# Altium schematic sheet CurrentSense.SchDoc
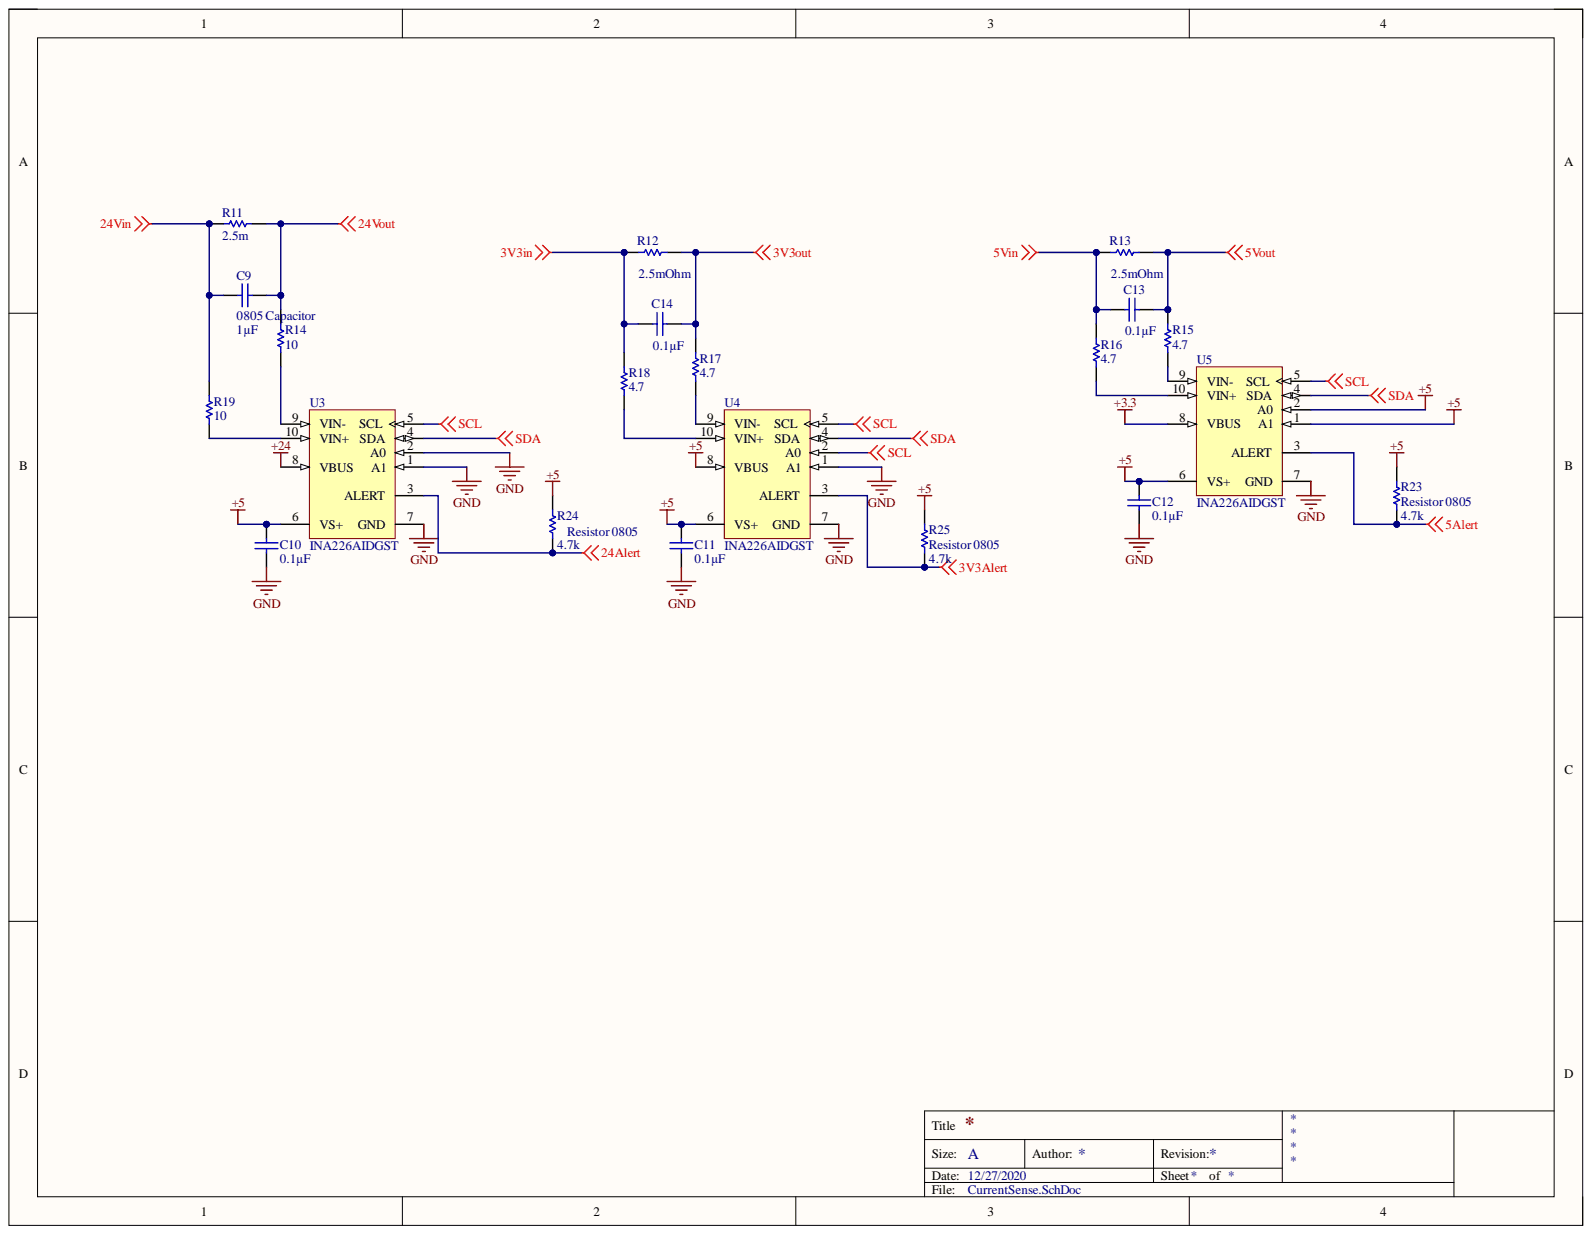
[diagram p0: CurrentSense.SchDoc]

## Parts
C9  0805 Capacitor  value 1µF  Case Size/Dimension: 0805  [Cap 0805]
C10  0805 Capacitor  value 0.1µF  [Cap 0805]
C11  0805 Capacitor  value 0.1µF  [Cap 0805]
C12  0805 Capacitor  value 0.1µF  [Cap 0805]
C13  0805 Capacitor  value 0.1µF  [Cap 0805]
C14  0805 Capacitor  value 0.1µF  [Cap 0805]
R11  2.5m  [Shunt Resistor]
R12  Resistor 0805  value 2.5mOhm  [RESC0805(2012)_N]
R13  value 2.5mOhm  [RESC0805(2012)_N]  (hidden comment: 2.5m)
R14  Resistor 0805  value 10  [RESC0805(2012)_N]
R15  Resistor 0805  value 4.7  [RESC0805(2012)_N]
R16  Resistor 0805  value 4.7  [RESC0805(2012)_N]
R17  Resistor 0805  value 4.7  [RESC0805(2012)_N]
R18  Resistor 0805  value 4.7  [RESC0805(2012)_N]
R19  Resistor 0805  value 10  [RESC0805(2012)_N]
R23  Resistor 0805  value 4.7k  [RESC0805(2012)_N]
R24  Resistor 0805  value 4.7k  [RESC0805(2012)_N]
R25  Resistor 0805  value 4.7k  [RESC0805(2012)_N]
U3  INA226AIDGST  [DGS0010A_N]
U4  INA226AIDGST  [DGS0010A_N]
U5  INA226AIDGST  [DGS0010A_N]

## Nets
3V3Alert: R25.1 U4.3(ALERT)
3V3in: C14.1 R12.1 R18.2
3V3out: C14.2 R12.2 R17.2
5Alert: C10.2 C11.2 C12.2 R23.1 R23.2 R24.2 R25.2 U3.6(VS+) U4.6(VS+) U4.8(VBUS) U5.1(A1) U5.2(A0) U5.3(ALERT) U5.6(VS+)
5Vin: C13.1 R13.1 R16.2
5Vout: C13.2 R13.2 R15.2
24Alert: R24.1 U3.3(ALERT) U3.8(VBUS)
24Vin: C9.1 R11.1 R19.2
24Vout: C9.2 R11.2 R14.2
+3.3: U5.8(VBUS)
GND: C10.1 C11.1 C12.1 U3.1(A1) U3.2(A0) U3.7(GND) U4.1(A1) U4.7(GND) U5.7(GND)
SCL: U3.5(SCL) U4.2(A0) U4.5(SCL) U5.5(SCL)
SDA: U3.4(SDA) U4.4(SDA) U5.4(SDA)
NetR14_1: R14.1 U3.9(VIN-)
NetR15_1: R15.1 U5.9(VIN-)
NetR16_1: R16.1 U5.10(VIN+)
NetR17_1: R17.1 U4.9(VIN-)
NetR18_1: R18.1 U4.10(VIN+)
NetR19_1: R19.1 U3.10(VIN+)

## Library data of the parts
C9 C13 C14 = CMP-002-0000-1: Standard Capacitor; Tolerance (%): ±10%; Component Kind: Standard; Component Type: Standard; ComponentLink1Description: Digi-Key_Purchase_URL; ComponentLink1URL: https://www.digikey.com/product-detail/en/kemet/C0805C104Z5VACTU/399-1177-1-ND/411452; ComponentLink2Description: Datasheet Link; ComponentLink2URL: https://content.kemet.com/datasheets/KEM_C1005_Y5V_SMD.pdf; Design Item ID: 0805 Capacitor; Digi-Key_Part_Number: 399-1177-1-ND; Digikey URL: https://www.digikey.com/product-detail/en/kemet/C0805C104Z5VACTU/399-1177-1-ND/411452; Mounting Technology: SurfaceMount; Package Description: 2-Pin Surface Mount Device, Body 2.01 x 1.25 mm; Package Reference: 0805; Pin Count: 2; Source: Standard Cap.SchLib; Library Name: University of Washington - Husky Robotics Team
  C13 C14: Case Size/Dimension: 0805
C10-C12 = 0805 Capacitor: Standard Capacitor; Tolerance (%): ±10%; Case Size/Dimension: 0805; Component Kind: Standard; ComponentLink2Description: Digi-Key_Purchase_URL; ComponentLink2URL: https://www.digikey.com/product-detail/en/kemet/C0805C104Z5VACTU/399-1177-1-ND/411452; ComponentLink3Description: Datasheet Link; ComponentLink3URL: https://content.kemet.com/datasheets/KEM_C1005_Y5V_SMD.pdf; Digi-Key_Part_Number: 399-1177-1-ND; Digikey URL: https://www.digikey.com/product-detail/en/kemet/C0805C104Z5VACTU/399-1177-1-ND/411452; Mounting Technology: SurfaceMount; Package Description: 2-Pin Surface Mount Device, Body 2.01 x 1.25 mm; Package Reference: 0805
R11 = CMP-017-0001-1: Component Kind: Standard; ComponentLink1Description: Digi-Key_Purchase_URL; ComponentLink1URL: https://www.digikey.com/en/products/detail/vishay-dale/SR32L500FE66/7560303?s=N4IgTCBcDaIM4CcDMYA2BWADJkBdAvkA; ComponentLink2Description: Datasheet_Link; ComponentLink2URL: https://www.vishay.com/docs/31067/sr.pdf; Design Item ID: Current Sensing resistor; Digi-Key_Part_Number: 541-3933-ND; Library Name: University of Washington - Husky Robotics Team; Source: CAN Termination Resistor 0805.SchLib
R12 R23-R25 = CMP-006-0001-1: Generic 0805 Resistor; Case-EIA: 0805; Case-Metric: 2012; Power: 0.125 W; Tolerance: 5 %; Component Kind: Standard; Component Type: Standard; ComponentLink1Description: Digi-Key_Purchase_Link; ComponentLink1URL: https://www.digikey.com/product-detail/en/rohm-semiconductor/ESR10EZPJ121/RHM120KCT-ND/1762959; ComponentLink2Description: Datasheet Link; ComponentLink2URL: https://d1d2qsbl8m0m72.cloudfront.net/en/products/databook/datasheet/passive/resistor/chip_resistor/esr-e.pdf; Design Item ID: Resistor 0805; Digi-Key_Part_Number: RHM120KCT-ND; Pin Count: 2; Technology: SMT; Library Name: University of Washington - Husky Robotics Team; Source: CAN Termination Resistor 0805.SchLib
R13 = CMP-006-0001-1: Generic 0805 Resistor; Case-EIA: 0805; Case-Metric: 2012; Power: 0.125 W; Tolerance: 5 %; Value: 2.5mOhm; Component Kind: Standard; Component Type: Standard; ComponentLink1Description: Digi-Key_Purchase_Link; ComponentLink1URL: https://www.digikey.com/product-detail/en/rohm-semiconductor/ESR10EZPJ121/RHM120KCT-ND/1762959; ComponentLink2Description: Datasheet Link; ComponentLink2URL: https://d1d2qsbl8m0m72.cloudfront.net/en/products/databook/datasheet/passive/resistor/chip_resistor/esr-e.pdf; Design Item ID: Resistor 0805; Digi-Key_Part_Number: RHM120KCT-ND; Pin Count: 2; Technology: SMT; Library Name: University of Washington - Husky Robotics Team; Source: CAN Termination Resistor 0805.SchLib
R14-R19 = Resistor 0805: Generic 0805 Resistor; Case-EIA: 0805; Case-Metric: 2012; Power: 0.125 W; Tolerance: 5 %; Component Kind: Standard; ComponentLink1Description: Digi-Key_Purchase_Link; ComponentLink1URL: https://www.digikey.com/product-detail/en/rohm-semiconductor/ESR10EZPJ121/RHM120KCT-ND/1762959; ComponentLink2Description: Datasheet Link; ComponentLink2URL: https://d1d2qsbl8m0m72.cloudfront.net/en/products/databook/datasheet/passive/resistor/chip_resistor/esr-e.pdf; Digi-Key_Part_Number: RHM120KCT-ND; Technology: SMT; Library Name: Husky Robotics Standard Library 2019-2020.IntLib
U3-U5 = CMP-0704-00057-2: High-Side Measurement, Bi-Directional Current / Power Monitor with I2C Interface, 2.7 to 5.5 V, -40 to 125 degC, 10-pin SOP (DGS10), Green (RoHS & no Sb/Br); CMRR(Min)(dB): 126; Common Mode Range(Max)(V): 36; Common Mode Range(Min)(V): 0; Gain(V/V): 1; Input Offset (+/-)(Max)(uV): 10; Input Offset Drift (+/-)(Max)(uV/Degrees Celsius): 0.1; Quiescent Current (+/-)(Typ)(mA): 0.33; Rating: Catalog; Small-Signal Bandwidth(Typ)(MHz): 0.007; SubFamily: Current Shunt Monitor; Vs(Max)(V): 5.5; Vs(Min)(V): 2.7; Code_JEDEC: MO-153; Component Kind: Standard; ComponentLink1Description: Manufacturer URL; ComponentLink1URL: http://www.ti.com/; ComponentLink2Description: Datasheet; ComponentLink2URL: http://www.ti.com/general/docs/lit/getliterature.tsp?genericPartNumber=INA226&fileType=pdf; ComponentLink3Description: Package Specification; ComponentLink3URL: http://www.ti.com/lit/ml/mpds035b/mpds035b.pdf; DatasheetVersion: SBOS547; Eco-Plan: Green (RoHS & no Sb/Br); Library Name: Altium Content Vault; Mounting Technology: Surface Mount; PackageDescription: 10-Pin Plastic Small Outline, Body 3 x 3 mm, Pitch 0.5 mm; PackageReference: DGS0010A; PackageVersion: 4073272/C, 02/2004; PartNumber: INA226AIDGST
